# Altium schematic sheet Sheet1.SchDoc
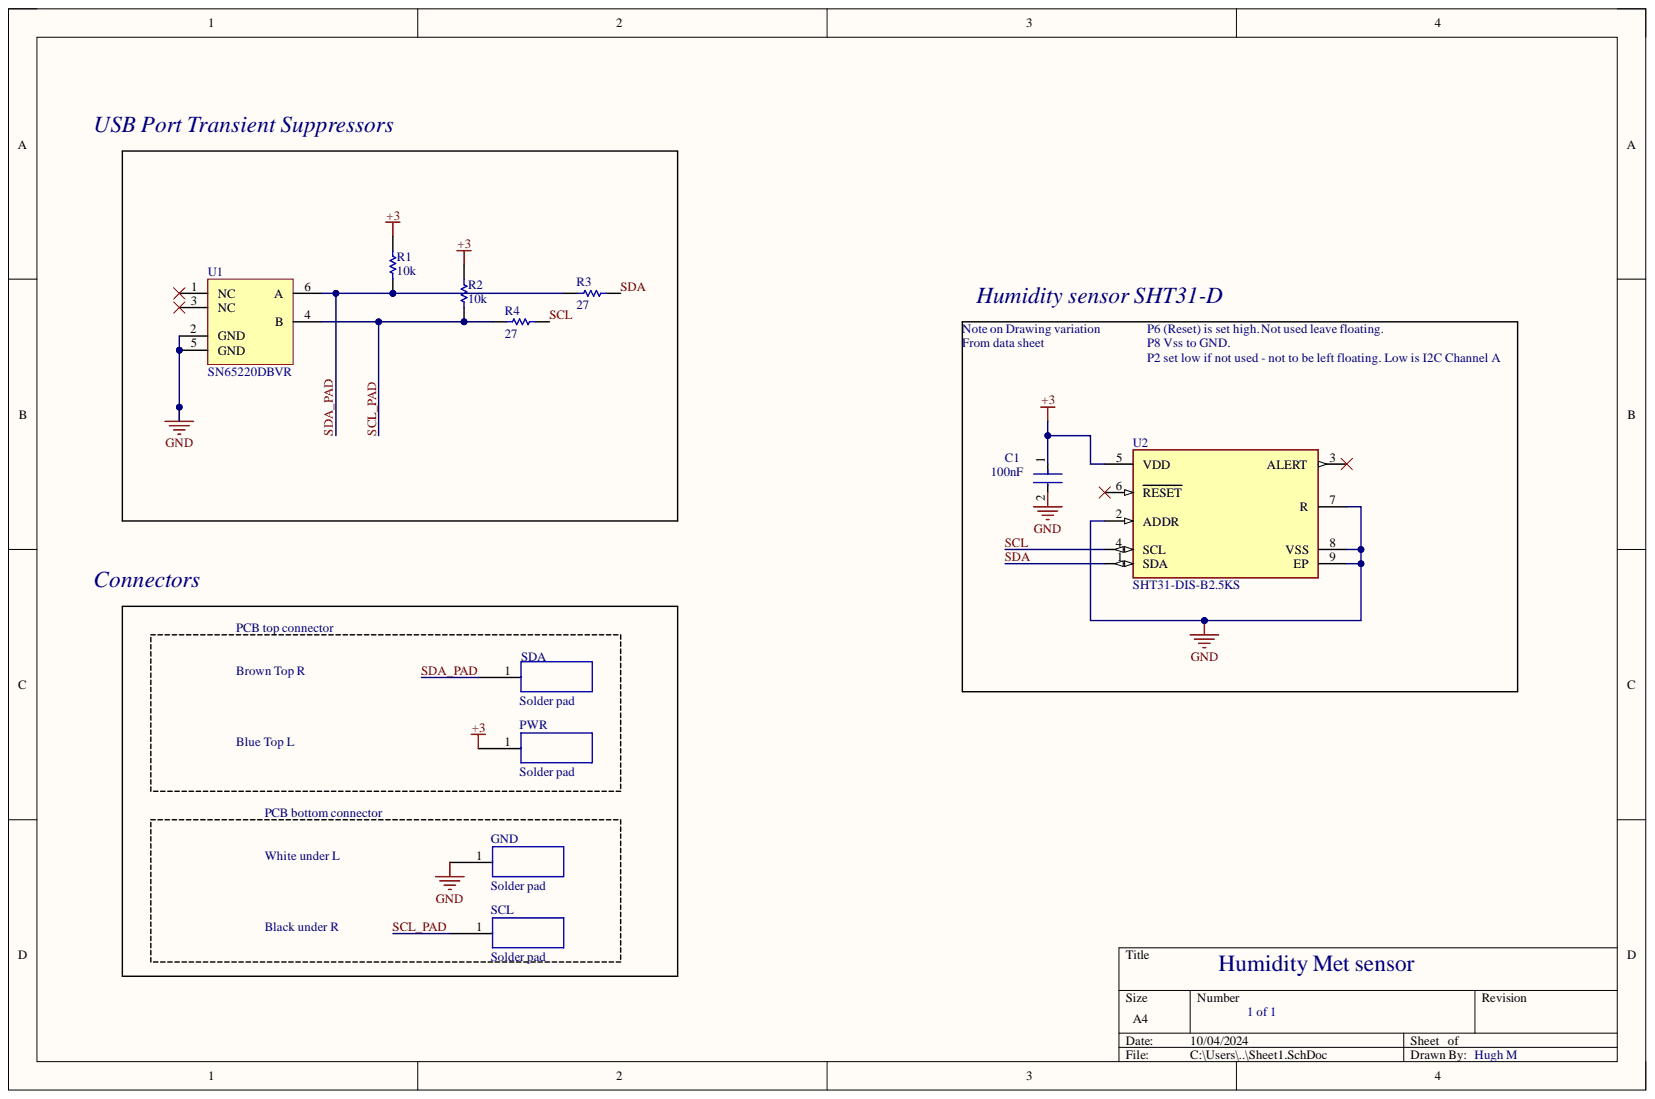
[diagram p0: Sheet1.SchDoc]

## Parts
C1  100nF  [FP-C0402-BB-MFG]
GND  Solder pad  [pad]
PWR  Solder pad  [pad]
R1  10k  [RESC1005X40X25LL05T10]
R2  10k  [RESC1005X40X25LL05T10]
R3  27  [RESC1005X40X25LL05T10]
R4  27  [RESC1005X40X25LL05T10]
SCL  Solder pad  [pad]
SDA  Solder pad  [pad]
U1  SN65220DBVR  [DBV0006A_M]
U2  SHT31-DIS-B2.5KS  [FP-SHT31-DIS-B2_5KS-MFG]

## Nets
+3: C1.1 PWR.1 R1.2 R2.2 U2.5(VDD)
GND: C1.2 GND.1 U1.2(GND) U1.5(GND) U2.2(ADDR) U2.7(R) U2.8(VSS) U2.9(EP)
SCL: R4.2 U2.4(SCL)
SCL_PAD: R2.1 R4.1 SCL.1 U1.4(B)
SDA: R3.2 U2.1(SDA)
SDA_PAD: R1.1 R3.1 SDA.1 U1.6(A)

Not connected: U1 1(NC) 3(NC); U2 3(ALERT) 6(RESET)

## Library data of the parts
C1 = CMP-2008-02046-2: CAP CER 0.1UF 10V X5R 0402; Manufacturer: Kemet; Manufacturer Part Number: C0402C104K8PACTU; Capacitance: 0.10 μF; Material: Ceramic; Temperature Coefficient: 15%; Tolerance: 0.1; Value: 0.10 μF; Automotive: No; Category: Cap; Component Kind: Standard; ComponentLink2Description: Datasheet; ComponentLink2URL: https://content.kemet.com/datasheets/KEM_C1002_X7R_SMD.pdf; Device Class L1: Passive Components; Device Class L2: Capacitors; Device Class L3: Ceramic Capacitors; Digikey Description: CAP CER 0.1UF 10V X5R 0402; Height: 0.55mm; Ipc Land Pattern Name: CAPC10050X50; Lead Free: Yes; Library Name: Altium Content Vault; Mouser Description: Capacitor 100 nF 10 VDC 0402; Octopart: https://octopart.com/c0402c104k8pactu-kemet-140039; Package: C0402-BB; RoHS: TRUE; Temperature: -55°C to +125°C; Temperature Characteristic: X5R; Temperature Range High: +125°C; Temperature Range Low: -55°C; Voltage Rating: 10 V
GND PWR SCL SDA = pad: Component Kind: Standard; Library Name: pad1.SchLib
R1 R2 = CMP-2002-07353-1: Manufacturer: Yageo / Phycomp; Part Number: RC0402FR-0710KL; Component Kind: Standard; Library Name: Altium Content Vault
R3 R4 = CMP-2002-07055-1: Manufacturer: Yageo / Phycomp; Part Number: RC0402FR-0727RL; Component Kind: Standard; Library Name: Altium Content Vault
U1 = CMP-0326-00064-3: Single Universal Serial Bus Port Transient Suppressor, 2 Channels, -40 to +85 degC, 6-pin SOT-23 (DBV), Green (RoHS & no Sb/Br); Manufacturer: Texas Instruments; Breakdown Voltage(Min)(V): 7; IO Capacitance(Typ)(pF): 35; IO Leakage Current(nA): 1000; SubFamily: ESD Solution; USB Full Speed: TRUE; Component Kind: Standard; ComponentLink1Description: Manufacturer URL; ComponentLink1URL: http://www.ti.com/; ComponentLink2Description: Datasheet; ComponentLink2URL: http://www.ti.com/general/docs/lit/getliterature.tsp?genericPartNumber=SN65220&fileType=pdf; ComponentLink3Description: URL Link1; ComponentLink3URL: SamplesURL:http://www.ti.com/product/sn65220#samples; DatasheetVersion: SLLS266G; Eco-Plan: Green (RoHS & no Sb/Br); Library Name: Altium Content Vault; Mounting Technology: Surface Mount; PackageDescription: 6-Pin Plastic Small-Outline Package, 2.9 x 1.6 mm Body, 0.95 mm Pitch, 2.8 mm Lead Span; PackageReference: DBV0006A; PackageVersion: revK, Mar-2006; PartNumber: SN65220DBVR
U2 = CMP-144040-000009-1: SENSOR HUMID/TEMP 5V I2C 2% SMD; Component Kind: Standard; Library Name: Altium Content Vault; RoHS Compliant: Yes
